# Altium schematic sheet dc-dc.SchDoc(dc-dc)
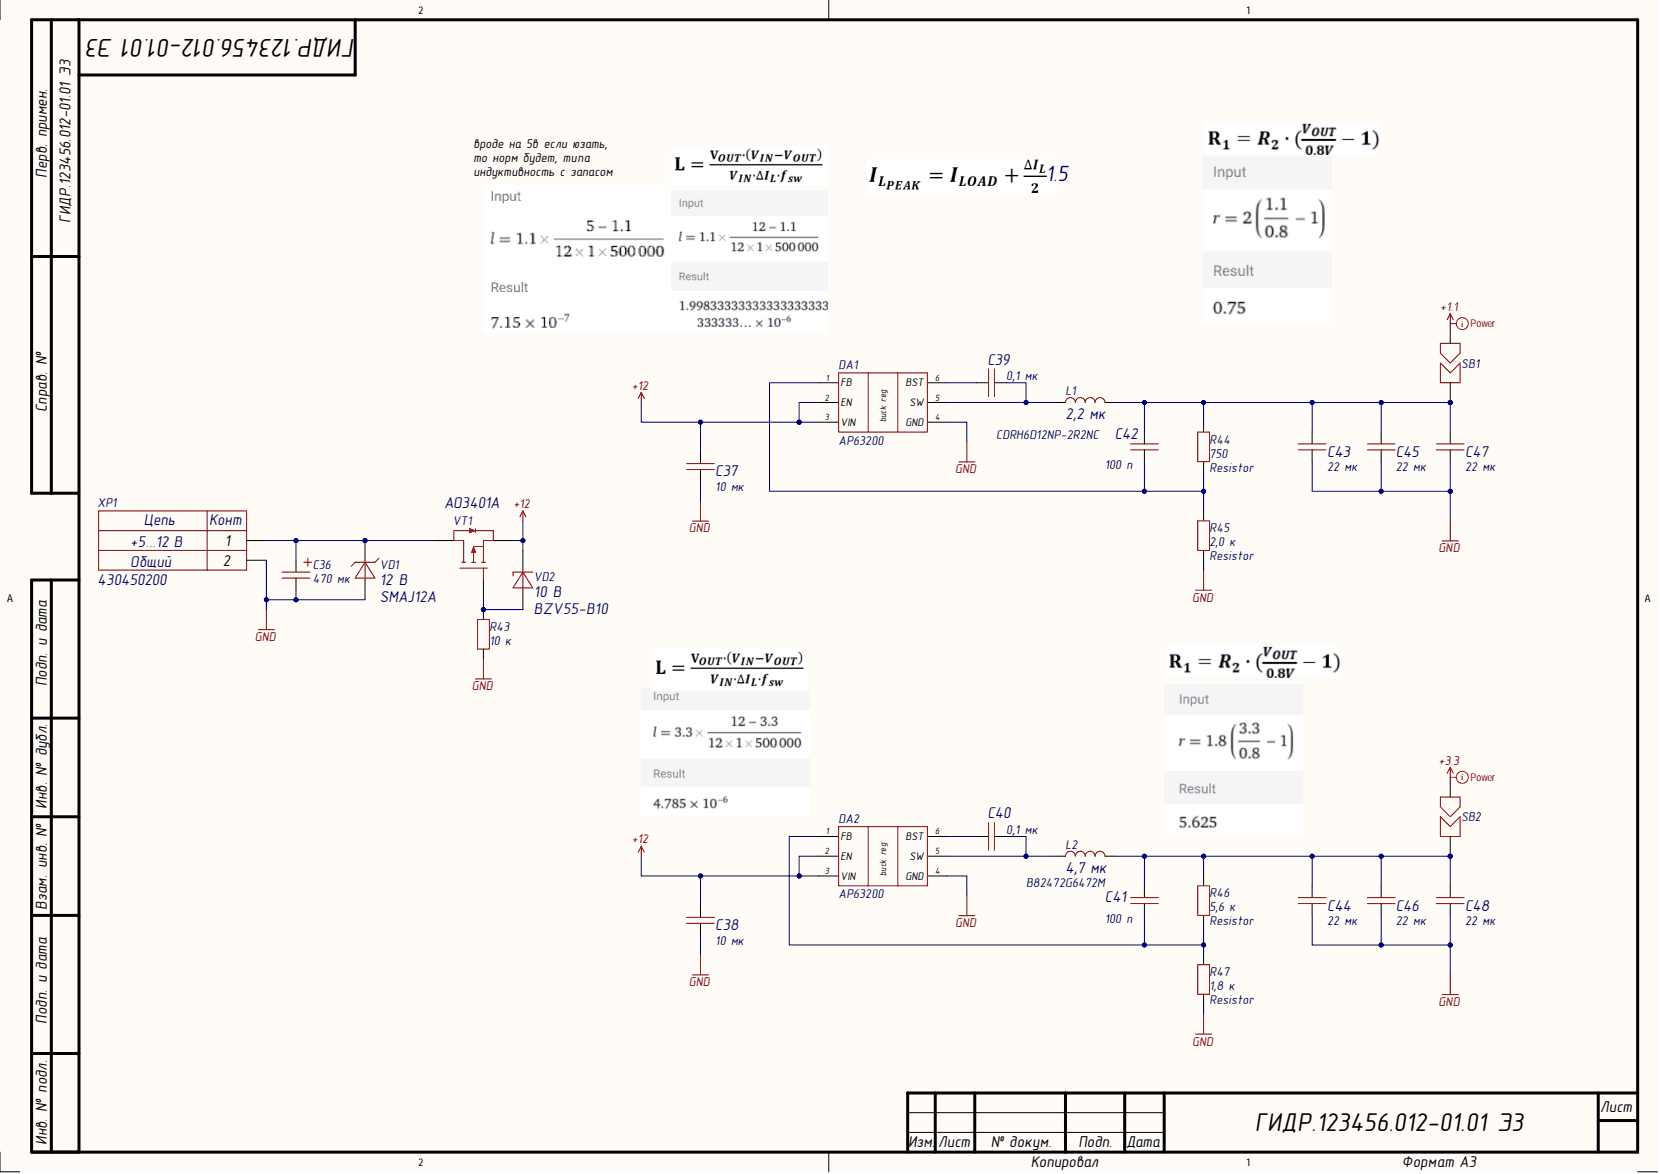
[diagram p0: dc-dc.SchDoc]

## Parts
C36  value 470 мк  [ECAP_SMD_10,3x10,3]  (hidden comment: Capacitor_polar)
C37  value 10 мк  [1210C]  (hidden comment: Capacitor)
C38  value 10 мк  [1210C]  (hidden comment: Capacitor)
C39  value 0,1 мк  [0603C]  (hidden comment: Capacitor)
C40  value 0,1 мк  [0603C]  (hidden comment: Capacitor)
C41  value 100 п  [0603C]  (hidden comment: Capacitor)
C42  value 100 п  [0603C]  (hidden comment: Capacitor)
C43  value 22 мк  [1210C]  (hidden comment: Capacitor)
C44  value 22 мк  [1210C]  (hidden comment: Capacitor)
C45  value 22 мк  [1210C]  (hidden comment: Capacitor)
C46  value 22 мк  [1210C]  (hidden comment: Capacitor)
C47  value 22 мк  [1210C]  (hidden comment: Capacitor)
C48  value 22 мк  [1210C]  (hidden comment: Capacitor)
DA1  AP63200  [TSOT26]
DA2  AP63200  [TSOT26]
L1  CDRH6D12NP-2R2NC  value 2,2 мк  Inductance (μH): 2,2  [CDRH6D12]
L2  B82472G6472M  value 4,7 мк  Inductance (μH): 4,7  [B82462G4]
R43  Resistor  value 10 к  [0603R]
R44  Resistor  value 750  [0603R]
R45  Resistor  value 2,0 к  [0603R]
R46  Resistor  value 5,6 к  [0603R]
R47  Resistor  value 1,8 к  [0603R]
SB1  SB  [0805SB]
SB2  SB  [0805SB]
VD1  SMAJ12A  value 12 В  [SMA]
VD2  BZV55-B10  value 10 В  [mini_MELF_3.5mm]
VT1  AO3401A  [SOT23-3]
XP1  430450200  Value1: +5...12 В  Value2: Общий  [MOLEX_MICRO-FIT_430450200]

## Nets
+1.1: SB1.1
+3.3: SB2.1
+12: C37.2 C38.2 DA1.2(EN) DA1.3(VIN) DA2.2(EN) DA2.3(VIN) VD2.2 VT1.2
GND: C36.2 C37.1 C38.1 C43.1 C44.1 C45.1 C46.1 C47.1 C48.1 DA1.4(GND) DA2.4(GND) R43.1 R45.1 R47.1 VD1.1 XP1.2
NetC36_1: C36.1 VD1.2 VT1.3 XP1.1
NetC39_1: C39.1 DA1.6(BST)
NetC39_2: C39.2 DA1.5(SW) L1.1
NetC40_1: C40.1 DA2.6(BST)
NetC40_2: C40.2 DA2.5(SW) L2.1
NetC41_1: C41.1 DA2.1(FB) R46.1 R47.2
NetC41_2: C41.2 C44.2 C46.2 C48.2 L2.2 R46.2 SB2.2
NetC42_1: C42.1 DA1.1(FB) R44.1 R45.2
NetC42_2: C42.2 C43.2 C45.2 C47.2 L1.3 R44.2 SB1.2
NetR43_2: R43.2 VD2.1 VT1.1

## Library data of the parts
C36 = CK016M471G10PKKKV00R: Конденсатор алюминиевый электролитический 470мкФ 16В ±20% (10х10)мм SMD 460мА 2000часов 105°C; Capacity (uF): 470; Database Table Name: Capacitors_electrolytic$; Tolerance: 20; Type: SMD Aluminum; Value: 470 мк; Voltage (V): 16; Автор: rreali; Component Kind: Standard; Datasheet: https://cdn.promelec.ru/upload/items/2023/12/25/suscon-ck.pdf; Library Name: Hydrolib.DbLib; Part Number: CK016M471G10PKKKV00R
C37 C38 = Capacitor_10u_10_35V_X7R_1210C: Конденсатор 1210C ёмкостью 10 мк 10%, 35 В, X7R; Capacity (nF): 10000; Database Table Name: Capacitors_ceramic$; Voltage (V): 35; Component Kind: Standard; Dielectric: X7R; Library Name: Hydrolib.DbLib; Part Number: Capacitor_10u_10_35V_X7R_1210C; Tolerance: 10%
C39 C40 = Capacitor_0,1u_10_50V_X7R_0603C: Конденсатор 0603C ёмкостью 0,1 мк 10%, 50 В, X7R; Capacity (nF): 100; Database Table Name: Capacitors_ceramic$; Voltage (V): 50; Component Kind: Standard; Dielectric: X7R; Library Name: Hydrolib.DbLib; Part Number: Capacitor_0,1u_10_50V_X7R_0603C; Tolerance: 10%
C41 C42 = Capacitor_100p_10_50V_X7R_0603C: Конденсатор 0603C ёмкостью 100 п 10%, 50 В, X7R; Capacity (nF): 0,1; Database Table Name: Capacitors_ceramic$; Voltage (V): 50; Component Kind: Standard; Dielectric: X7R; Library Name: Hydrolib.DbLib; Part Number: Capacitor_100p_10_50V_X7R_0603C; Tolerance: 10%
C43-C48 = Capacitor_22u_10_25V_X7R_1210C: Конденсатор 1210C ёмкостью 22 мк 10%, 25 В, X7R; Capacity (nF): 22000; Database Table Name: Capacitors_ceramic$; Voltage (V): 25; Component Kind: Standard; Dielectric: X7R; Library Name: Hydrolib.DbLib; Part Number: Capacitor_22u_10_25V_X7R_1210C; Tolerance: 10%
DA1 DA2 = AP63200: DC-DC понижающий преобразователь, Vin: 3.8 - 40 В, adj, 2 А; Database Table Name: Chips$; Component Kind: Standard; Library Name: Hydrolib.DbLib; Part Number: AP63200
L1 = CDRH6D12NP-2R2NC: Индуктивность на 2,2 мкГн, номинальный ток 2,5 А; Current: 2,5 А; Database Table Name: Inductance$; Автор: rreali; Component Kind: Standard; Library Name: Hydrolib.DbLib; Part Number: CDRH6D12NP-2R2NC
L2 = B82472G6472M: Индуктивность на 4,7 мкГн, номинальный ток 2,5 А; Current: 2,5 А; Database Table Name: Inductance$; Автор: rreali; Component Kind: Standard; Library Name: Hydrolib.DbLib; Part Number: B82472G6472M
R43 = Resistor_10k_1_0603: Резистор номиналом 10 кОм 1%; Database Table Name: Resistors$; Component Kind: Standard; Library Name: Hydrolib.DbLib; Part Number: Resistor_10k_1_0603; Tolerance: 1%
R44 = Resistor_750_1_0603: Резистор номиналом 750 Ом 1%; Database Table Name: Resistors$; Component Kind: Standard; Library Name: Hydrolib.DbLib; Part Number: Resistor_750_1_0603; Tolerance: 1%
R45 = Resistor_2.0k_1_0603: Резистор номиналом 2,0 кОм 1%; Database Table Name: Resistors$; Component Kind: Standard; Library Name: Hydrolib.DbLib; Part Number: Resistor_2.0k_1_0603; Tolerance: 1%
R46 = Resistor_5.6k_1_0603: Резистор номиналом 5,6 кОм 1%; Database Table Name: Resistors$; Component Kind: Standard; Library Name: Hydrolib.DbLib; Part Number: Resistor_5.6k_1_0603; Tolerance: 1%
R47 = Resistor_1.8k_1_0603: Резистор номиналом 1,8 кОм 1%; Database Table Name: Resistors$; Component Kind: Standard; Library Name: Hydrolib.DbLib; Part Number: Resistor_1.8k_1_0603; Tolerance: 1%
SB1 SB2 = SB: Solder bridge, мост припоя, запаиваемая перемычка, net tie, jumper; Database Table Name: Other$; Автор: rreali; Component Kind: Net Tie; Library Name: Hydrolib.DbLib; Part Number: SB
VD1 = SMAJ12A: Защитный диод на 12 В, рассеиваемая мощность 400 Вт; Database Table Name: TVS$; Power (W): 400; Voltage (V): 12; Автор: rreali; Component Kind: Standard; Library Name: Hydrolib.DbLib; Part Number: SMAJ12A
VD2 = BZV55-B10: Стабилитрон 10В, 2%, 0.5Вт; Accuracy: 0,02; Current: 5 мА; Database Table Name: Zener$; Power (W): 0.5; Voltage (V): 10; Автор: rreali; Component Kind: Standard; Datasheet: https://cdn.promelec.ru/upload/datasheet/b/7/BZV55_SER.pdf; Library Name: Hydrolib.DbLib; Part Number: BZV55-B10
VT1 = AO3401A: P-канальный MOSFET; Database Table Name: Transistors$; Component Kind: Standard; Library Name: Hydrolib.DbLib; Part Number: AO3401A
XP1 = 430450200: Разъем типа провод-плата, угловой, 3 мм, 2 контакт(-ов), Штыревой Разъем, Серия Micro-Fit для монтажа в отверстия; Database Table Name: Connectors$; System: Connector; Автор: rreali; Component Kind: Standard; Datasheet: https://www.molex.com/content/dam/molex/molex-dot-com/products/automated/en-us/salesdrawingpdf/430/43045/430450200_sd.pdf?inline; Library Name: Hydrolib.DbLib; Part Number: 430450200
